# Altium schematic sheet IR.SchDoc
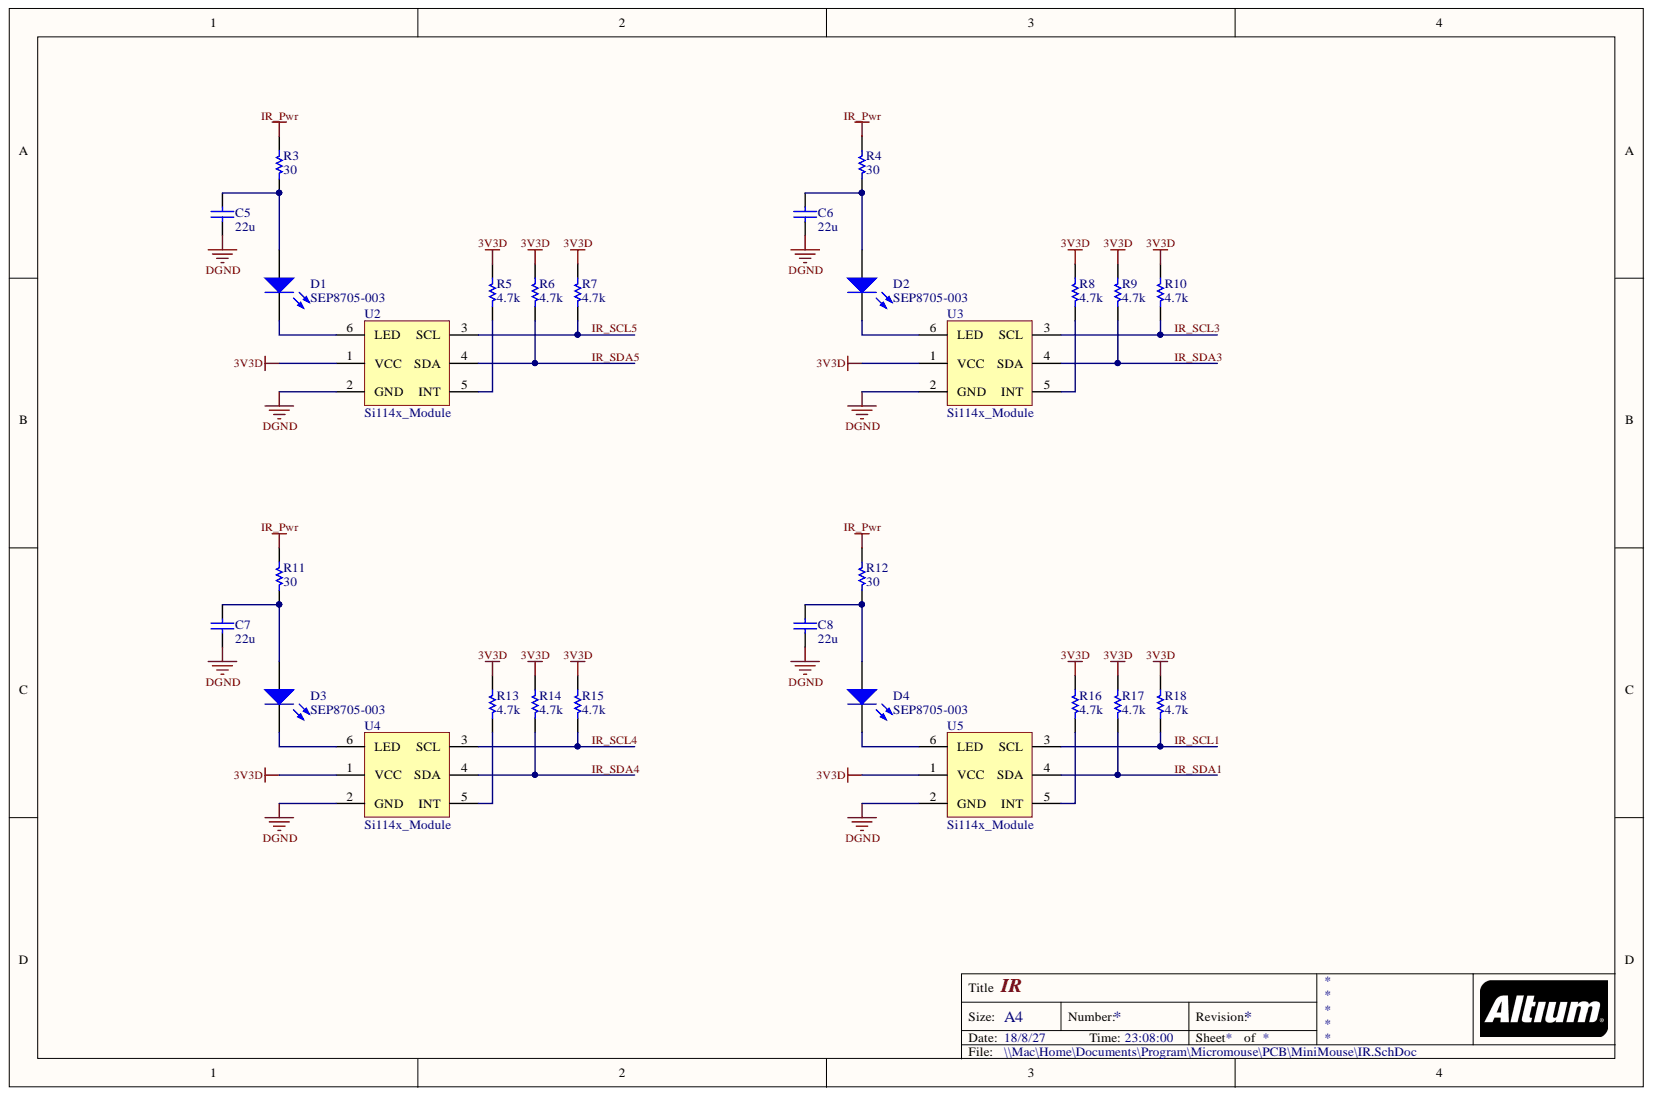
[diagram p0: IR.SchDoc]

## Parts
C5  22u  [CAPC1608[0603]]
C6  22u  [CAPC1608[0603]]
C7  22u  [CAPC1608[0603]]
C8  22u  [CAPC1608[0603]]
D1  SEP8705-003  [SEP8705_RA]
D2  SEP8705-003  [SEP8705_RA]
D3  SEP8705-003  [SEP8705_RA]
D4  SEP8705-003  [SEP8705_RA]
R3  30  [RESC1005[0402]]
R4  30  [RESC1005[0402]]
R5  4.7k  [RESC1005[0402]]
R6  4.7k  [RESC1005[0402]]
R7  4.7k  [RESC1005[0402]]
R8  4.7k  [RESC1005[0402]]
R9  4.7k  [RESC1005[0402]]
R10  4.7k  [RESC1005[0402]]
R11  30  [RESC1005[0402]]
R12  30  [RESC1005[0402]]
R13  4.7k  [RESC1005[0402]]
R14  4.7k  [RESC1005[0402]]
R15  4.7k  [RESC1005[0402]]
R16  4.7k  [RESC1005[0402]]
R17  4.7k  [RESC1005[0402]]
R18  4.7k  [RESC1005[0402]]
U2  Si114x_Module  [Si114x_Module_A]
U3  Si114x_Module  [Si114x_Module_A]
U4  Si114x_Module  [Si114x_Moudle_B]
U5  Si114x_Module  [Si114x_Moudle_B]

## Nets
3V3D: R5.1 R6.2 R7.2 R8.1 R9.2 R10.2 R13.1 R14.2 R15.2 R16.1 R17.2 R18.2 U2.1(VCC) U3.1(VCC) U4.1(VCC) U5.1(VCC)
DGND: C5.1 C6.1 C7.1 C8.1 U2.2(GND) U3.2(GND) U4.2(GND) U5.2(GND)
IR_Pwr: R3.2 R4.2 R11.2 R12.2
IR_SCL1: R18.1 U5.3(SCL)
IR_SCL3: R10.1 U3.3(SCL)
IR_SCL4: R15.1 U4.3(SCL)
IR_SCL5: R7.1 U2.3(SCL)
IR_SDA1: R17.1 U5.4(SDA)
IR_SDA3: R9.1 U3.4(SDA)
IR_SDA4: R14.1 U4.4(SDA)
IR_SDA5: R6.1 U2.4(SDA)
NetC5_2: C5.2 D1.1 R3.1
NetC6_2: C6.2 D2.1 R4.1
NetC7_2: C7.2 D3.1 R11.1
NetC8_2: C8.2 D4.1 R12.1
NetD1_2: D1.2 U2.6(LED)
NetD2_2: D2.2 U3.6(LED)
NetD3_2: D3.2 U4.6(LED)
NetD4_2: D4.2 U5.6(LED)
NetR5_2: R5.2 U2.5(INT)
NetR8_2: R8.2 U3.5(INT)
NetR13_2: R13.2 U4.5(INT)
NetR16_2: R16.2 U5.5(INT)

## Library data of the parts
C5-C8 = Cap: Capacitor; Component Kind: Standard; LatestRevisionDate: 17-Jul-2002; LatestRevisionNote: Re-released for DXP Platform.; Library Name: Miscellaneous Devices.IntLib; PackageDescription: Radial Cap, Thru-Hole; 2 Leads; 0.3 in Pin Spacing; PackageReference: RAD-0.3; Published: 8-Jun-2000; Signal Integrity: Cap; Simulation: CAP; Value: 22u; Publisher: Altium Limited
D1-D4 = LED1: Typical RED GaAs LED; Component Kind: Standard; LatestRevisionDate: 08-Jul-2005; LatestRevisionNote: Mech Layer 1 removed.; Library Name: Miscellaneous Devices.IntLib; PackageDescription: LED; 2 Leads; PackageReference: LED-1; Published: 8-Jun-2000; Simulation: LED1; Publisher: Altium Limited
R3-R18 = Res1: Resistor; Component Kind: Standard; LatestRevisionDate: 17-Jul-2002; LatestRevisionNote: Re-released for DXP Platform.; Library Name: Miscellaneous Devices.IntLib; PackageDescription: Axial Device, Thru-Hole; 2 Leads; 0.3 in Pin Spacing; PackageReference: AXIAL-0.3; Published: 8-Jun-2000; Signal Integrity: Res; Simulation: RESISTOR; Publisher: Altium Limited
  R3 R4 R11 R12: Value: 30
  R5-R10 R13-R18: Value: 4.7k
U2-U5 = Si114x_Module: Component Kind: Standard; Library Name: Si114x_Module.SchLib
